# Altium schematic sheet sunsensor.SchDoc(sunsensor)
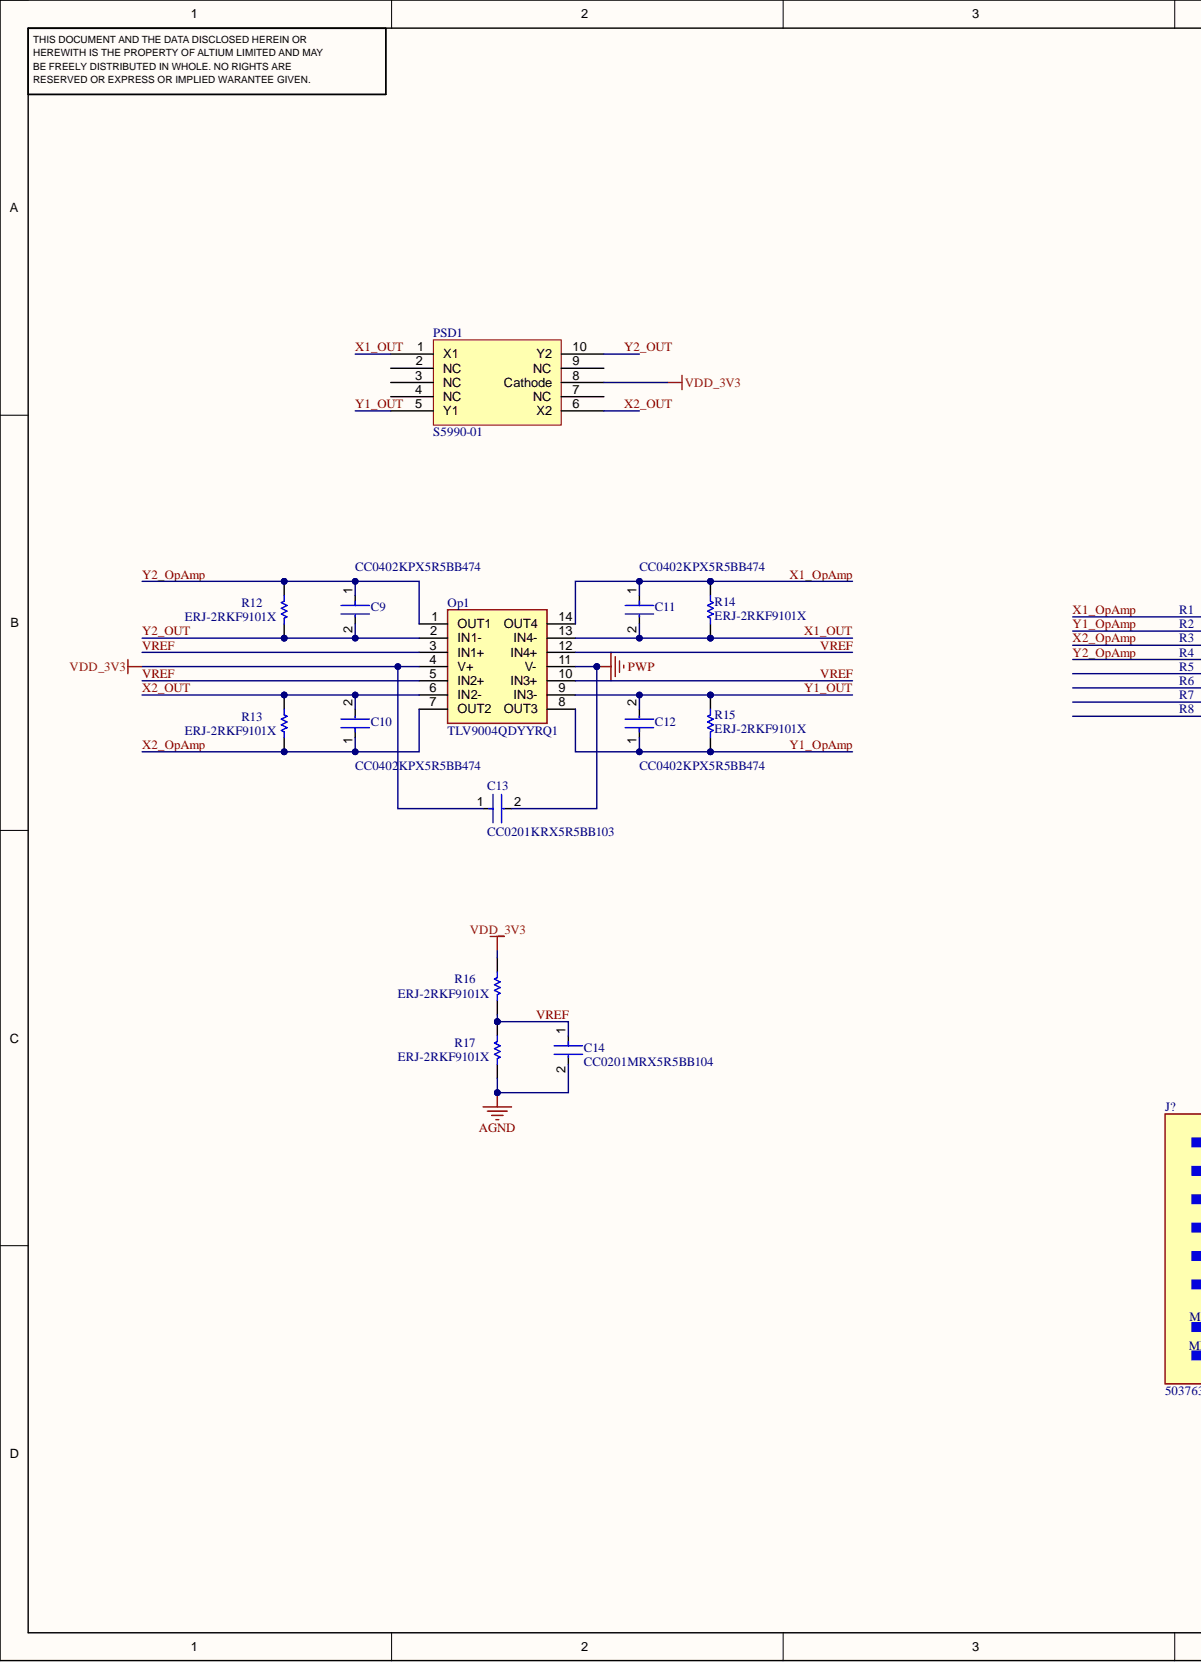
[diagram p0: sunsensor.SchDoc, left]
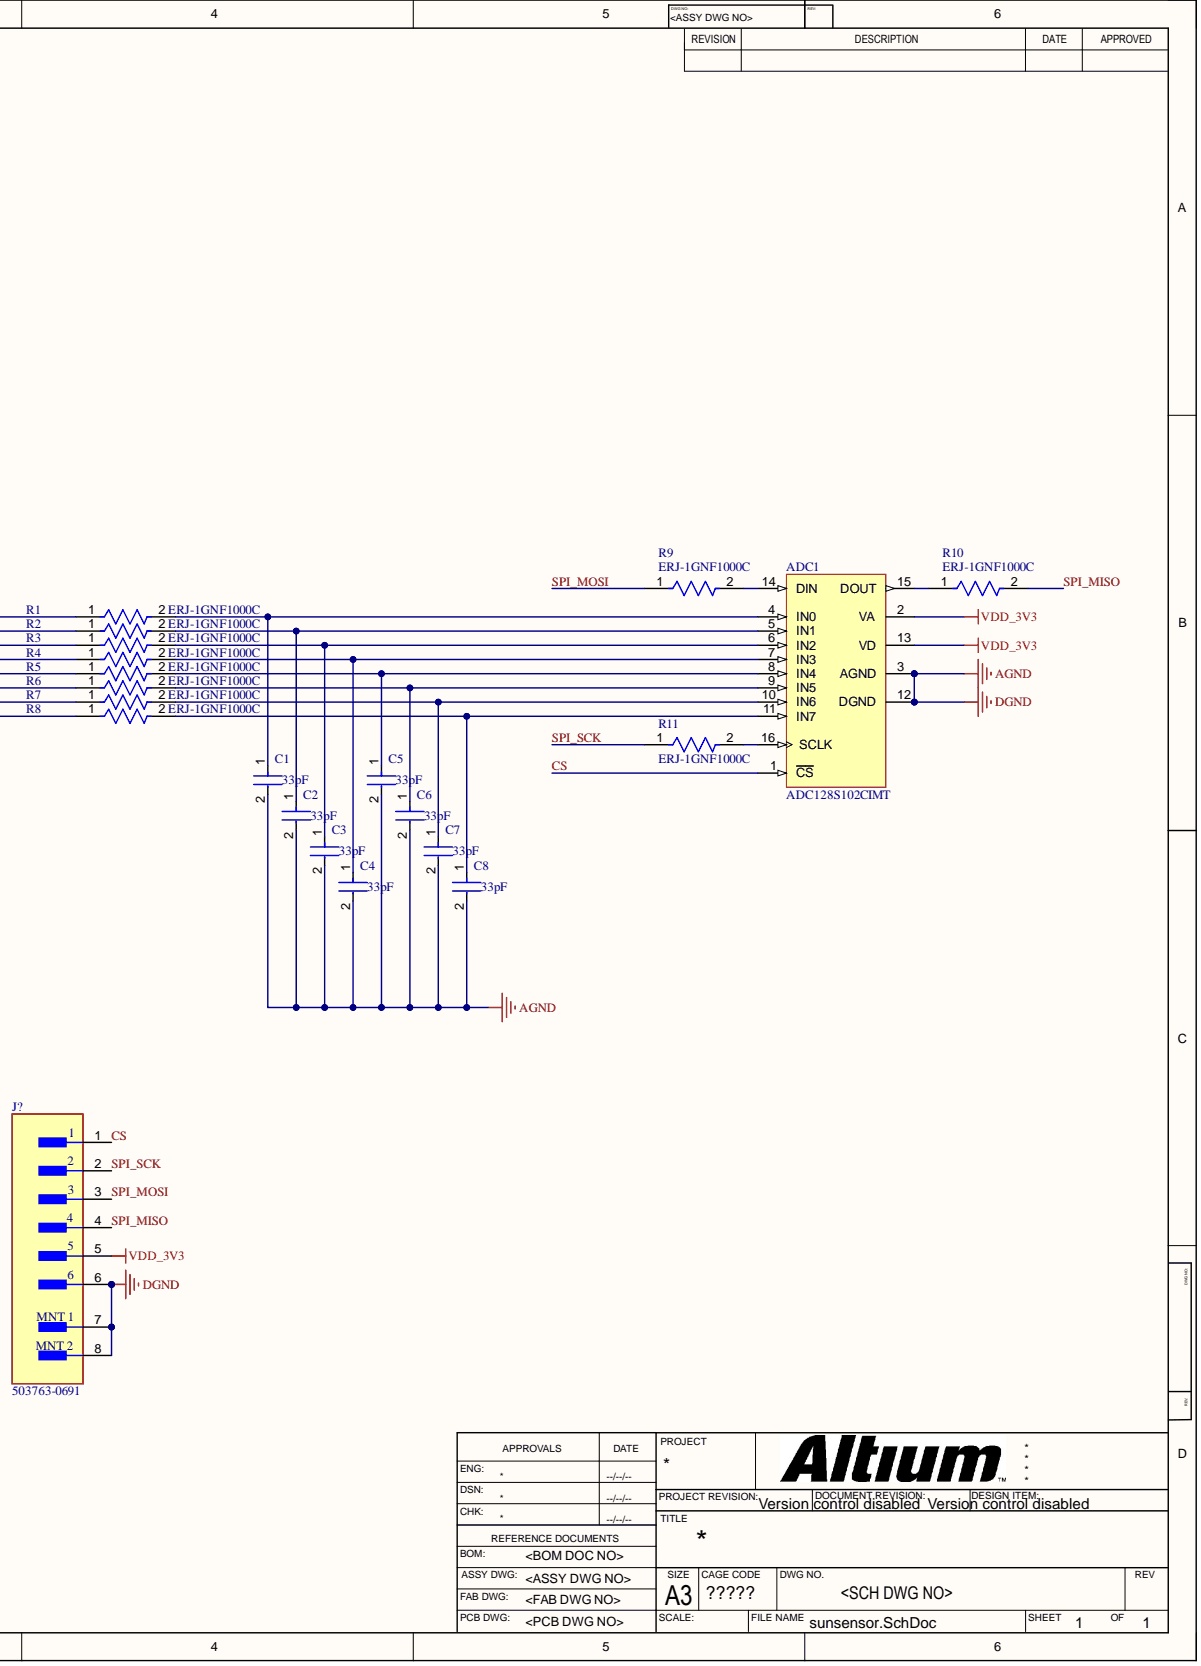
[diagram p1: sunsensor.SchDoc, right]

## Parts
ADC1  ADC128S102CIMT
C1  33pF
C2  33pF
C3  33pF
C4  33pF
C5  33pF
C6  33pF
C7  33pF
C8  33pF
C9  CC0402KPX5R5BB474
C10  CC0402KPX5R5BB474
C11  CC0402KPX5R5BB474
C12  CC0402KPX5R5BB474
C13  CC0201KRX5R5BB103
C14  CC0201MRX5R5BB104
J?  503763-0691
Op1  TLV9004QDYYRQ1
PSD1  S5990-01
R1  ERJ-1GNF1000C
R2  ERJ-1GNF1000C
R3  ERJ-1GNF1000C
R4  ERJ-1GNF1000C
R5  ERJ-1GNF1000C
R6  ERJ-1GNF1000C
R7  ERJ-1GNF1000C
R8  ERJ-1GNF1000C
R9  ERJ-1GNF1000C
R10  ERJ-1GNF1000C
R11  ERJ-1GNF1000C
R12  ERJ-2RKF9101X
R13  ERJ-2RKF9101X
R14  ERJ-2RKF9101X
R15  ERJ-2RKF9101X
R16  ERJ-2RKF9101X
R17  ERJ-2RKF9101X

## Nets
AGND: ADC1.3(AGND) ADC1.12(DGND) C1.2 C2.2 C3.2 C4.2 C5.2 C6.2 C7.2 C8.2 C14.2 J?.6 J?.7 J?.8 R17.1
PWP: C13.2 Op1.11(V-)
VDD_3V3: ADC1.2(VA) ADC1.13(VD) C13.1 J?.5 Op1.4(V+) PSD1.8(Cathode) R16.2
CS: ADC1.1(CS) J?.1
SPI_MISO: J?.4 R10.2
SPI_MOSI: J?.3 R9.1
SPI_SCK: J?.2 R11.1
VREF: C14.1 Op1.3(IN1+) Op1.5(IN2+) Op1.10(IN3+) Op1.12(IN4+) R16.1 R17.2
X1_OpAmp: C11.1 Op1.14(OUT4) R1.1 R14.2
X1_OUT: C11.2 Op1.13(IN4-) PSD1.1(X1) R14.1
X2_OpAmp: C10.1 Op1.7(OUT2) R3.1 R13.2
X2_OUT: C10.2 Op1.6(IN2-) PSD1.6(X2) R13.1
Y1_OpAmp: C12.1 Op1.8(OUT3) R2.1 R15.2
Y1_OUT: C12.2 Op1.9(IN3-) PSD1.5(Y1) R15.1
Y2_OpAmp: C9.1 Op1.1(OUT1) R4.1 R12.2
Y2_OUT: C9.2 Op1.2(IN1-) PSD1.10(Y2) R12.1
NetADC1_4: ADC1.4(IN0) C1.1 R1.2
NetADC1_5: ADC1.5(IN1) C2.1 R2.2
NetADC1_6: ADC1.6(IN2) C3.1 R3.2
NetADC1_7: ADC1.7(IN3) C4.1 R4.2
NetADC1_8: ADC1.8(IN4) C5.1 R5.2
NetADC1_9: ADC1.9(IN5) C6.1 R6.2
NetADC1_10: ADC1.10(IN6) C7.1 R7.2
NetADC1_11: ADC1.11(IN7) C8.1 R8.2
NetADC1_14: ADC1.14(DIN) R9.2
NetADC1_15: ADC1.15(DOUT) R10.1
NetADC1_16: ADC1.16(SCLK) R11.2

Not connected: PSD1 2(NC) 3(NC) 4(NC) 7(NC) 9(NC); R5 1; R6 1; R7 1; R8 1

## Library data of the parts
ADC1 = CMP-0054-00323-3: 8-Channel, 500 kSPS to 1 MSPS, 12-Bit A/D Converter, 16-pin TSSOP; Manufacturer: Texas Instruments; CompType: ADC IC; PackageTopMarking: NSUZXYTT*128S102*CIMT; PowerWise: Y; Code_JEDEC: MO-153-AB; Component Kind: Standard; ComponentLink1Description: Datasheet URL; ComponentLink1URL: http://www.ti.com/general/docs/lit/getliterature.tsp?genericPartNumber=ADC128S102&fileType=pdf; Library Name: Altium Content Vault; PackageDescription: Molded TSSOP, Jedec, 5 x 4.4 x 0.9mm Body, 16 Lead, 0.65mm Pitch; PackageReference: PW0016A; PinCount: 16; RoHS: N
C1-C8 = CMP-03422-001045-1: CAP CER 0.033UF 6.3V X5R 0201; Manufacturer: Yageo; Manufacturer Part Number: CC0201KRX5R5BB333; Capacitance: 33 nF; Material: Ceramic; Temperature Coefficient: 15%; Tolerance: 0.1; Value: 33 nF; Automotive: No; Category: Cap; Component Kind: Standard; ComponentLink2Description: Datasheet; ComponentLink2URL: http://www.yageo.com/documents/recent/UPY-GPHC_X5R_4V-to-50V_25.pdf; Device Class L1: Passive Components; Device Class L2: Capacitors; Device Class L3: Ceramic Capacitors; Digikey Description: CAP CER 0.033UF 6.3V X5R 0201; Footprint Url: http://www.yageo.com/exep/pages/download/literatures/UPY-C_GEN_24.pdf; Height: 0.33mm; Ipc Land Pattern Name: CAPC6030X30; Lead Free: Yes; Library Name: Altium Content Vault; Mouser Description: Cap Ceramic 0.033uF 6.3V X5R 10% SMD 0201 85°C Paper T/R; Octopart: https://octopart.com/cc0201krx5r5bb333-yageo-21711160; Package: CC0201-BA; RoHS: TRUE; Temperature: -55°C to +85°C; Temperature Characteristic: X5R; Temperature Range High: +85°C; Temperature Range Low: -55°C; Voltage Rating: 6.3 V
C9-C12 = CMP-03422-000951-1: CC0402 470 nF X5R 10.00% 6.3 V; Manufacturer: YAGEO; Automotive Grade: NA; Capacitance: 470 nF; Material: Ceramic; Temperature Coefficient: 30ppm/°C; Tolerance: 0.1; Value: 470 nF; Voltage Rating: 6.3 V; Automotive: No; Category: Cap; Component Kind: Standard; ComponentLink2Description: Datasheet; ComponentLink2URL: http://www.yageo.com/documents/recent/UPY-GP_NP0_16V-to-50V_16.pdf; Device Class L1: Passive Components; Device Class L2: Capacitors; Device Class L3: Ceramic Capacitors; Footprint Url: http://www.yageo.com/exep/pages/download/literatures/UPY-C_GEN_24.pdf; Height: 0.55mm; Ipc Land Pattern Name: CAPC10050X50; Lead Free: Yes; Library Name: Altium Content Vault; Manufacturer Part Number: CC0402KPX5R5BB474; Mouser Description: Multilayer Ceramic Capacitors MLCC - SMD/SMT 470nF 6.3V X5R 10%; Octopart: https://octopart.com/cc0402kpx5r5bb474-yageo-88496705; Package: CC0402; RoHS: TRUE; Temperature: -55°C to +125°C; Temperature Characteristic: X5R; Temperature Range High: +125°C; Temperature Range Low: -55°C
C13 = CMP-03422-000626-1: CAP CER 10000PF 6.3V X5R 0201; Manufacturer: Yageo; Capacitance: 10 nF; Material: Ceramic; Temperature Coefficient: 15%; Tolerance: 0.1; Value: 10 nF; Automotive: No; Category: Cap; Component Kind: Standard; ComponentLink2Description: Datasheet; ComponentLink2URL: http://www.yageo.com/documents/recent/UPY-GPHC_X5R_4V-to-50V_25.pdf; Device Class L1: Passive Components; Device Class L2: Capacitors; Device Class L3: Ceramic Capacitors; Digikey Description: CAP CER 10000PF 6.3V X5R 0201; Footprint Url: http://www.yageo.com/exep/pages/download/literatures/UPY-C_GEN_24.pdf; Height: 0.33mm; Ipc Land Pattern Name: CAPC6030X30; Lead Free: Yes; Library Name: Altium Content Vault; Manufacturer Part Number: CC0201KRX5R5BB103; Mouser Description: Multilayer Ceramic Capacitors MLCC - SMD/SMT 10nF 6.3V X5R 10%; Octopart: https://octopart.com/cc0201krx5r5bb103-yageo-9292638; Package: CC0201-BA; RoHS: TRUE; Temperature: -55°C to +85°C; Temperature Characteristic: X5R; Temperature Range High: +85°C; Temperature Range Low: -55°C; Voltage Rating: 6.3 V
C14 = CMP-03422-001169-1: CAP CER 0.1UF 6.3V X5R 0201; Manufacturer: Yageo; Capacitance: 100 nF; Material: Ceramic; Temperature Coefficient: 15%; Tolerance: 0.2; Value: 100 nF; Automotive: No; Category: Cap; Component Kind: Standard; ComponentLink2Description: Datasheet; ComponentLink2URL: http://www.yageo.com/documents/recent/UPY-GPHC_X5R_4V-to-50V_25.pdf; Device Class L1: Passive Components; Device Class L2: Capacitors; Device Class L3: Ceramic Capacitors; Digikey Description: CAP CER 0.1UF 6.3V X5R 0201; Footprint Url: http://www.yageo.com/exep/pages/download/literatures/UPY-C_GEN_24.pdf; Height: 0.33mm; Ipc Land Pattern Name: CAPC6030X30; Lead Free: Yes; Library Name: Altium Content Vault; Manufacturer Part Number: CC0201MRX5R5BB104; Mouser Description: Multilayer Ceramic Capacitors MLCC - SMD/SMT 100nF 6.3V X5R 20%; Octopart: https://octopart.com/cc0201mrx5r5bb104-yageo-33242267; Package: CC0201-BA; RoHS: TRUE; Temperature: -55°C to +85°C; Temperature Characteristic: X5R; Temperature Range High: +85°C; Temperature Range Low: -55°C; Voltage Rating: 6.3 V
J? = CMP-04776-000530-1: CONN HEADER SMD R/A 6POS 1MM; Component Kind: Standard; Library Name: Altium Content Vault
Op1 = TLV9004QDYYRQ1: Integrated Circuit; Manufacturer_Name: Texas Instruments; Arrow Part Number: TLV9004QDYYRQ1; Arrow Price/Stock: https://www.arrow.com/en/products/tlv9004qdyyrq1/texas-instruments?utm_currency=USD&region=nac; Component Kind: Standard; Datasheet Link: https://www.ti.com/lit/ds/symlink/tlv9004-q1.pdf?ts=1653903936376&ref_url=https%253A%252F%252Fwww.ti.com%252Fproduct%252FTLV9004-Q1%252Fpart-details%252FTLV9004QDYYRQ1; Height: 1.1mm; Manufacturer_Part_Number: TLV9004QDYYRQ1; Mouser Part Number: 595-TLV9004QDYYRQ1; Mouser Price/Stock: https://www.mouser.co.uk/ProductDetail/Texas-Instruments/TLV9004QDYYRQ1?qs=A6eO%252BMLsxmQxCVD4nSHH0g%3D%3D
PSD1 = CMP-00026-00001-1: Optoelectronic Device; Component Kind: Standard; Max Operating Temperature: 60°C; Min Operating Temperature: -20°C; RoHS Compliant: Yes; Library Name: Hitesh Bhoyar
R1-R11 = CMP-2004-03443-2: RES SMD 100 OHM 1% 1/20W 0201; Manufacturer: Panasonic Electronic Components; Automotive Grade: Grade 1; Power Rating: 0.05W; Resistance: 100Ω; Temperature Coefficient: 200ppm/°C; Value: 100Ω; Voltage Rating: 25V; Automotive: Yes; Category: Res; Component Kind: Standard; ComponentLink2Description: Datasheet; ComponentLink2URL: https://industrial.panasonic.com/cdbs/www-data/pdf/RDA0000/AOA0000C304.pdf; Composition: Thick Film; Device Class L1: Passive Components; Device Class L2: Resistors; Device Class L3: Chip SMD Resistors; Digikey Description: RES SMD 100 OHM 1% 1/20W 0201; Footprint Url: https://industrial.panasonic.com/cdbs/www-data/pdf/RDA0000/DMA0000COL17.pdf; Height: 0.26mm; Lead Free: Yes; Library Name: Altium Content Vault; Manufacturer Part Number: ERJ-1GNF1000C; Mouser Description: Thick Film Resistors 0201 100ohm 1% HalogenFree AEC-Q200; Octopart: https://octopart.com/erj-1gnf1000c-panasonic-55419695; Package: ERJ1GN; RoHS: TRUE; Series: ERJ; Temperature: -55°C to +125°C; Temperature Range High: +125°C; Temperature Range Low: -55°C; Tolerance: 1%
R12-R17 = CMP-1011-00619-2: Manufacturer: Panasonic; Component Kind: Standard; Library Name: Altium Content Vault; Part Number: ERJ-2RKF9101X
